# Altium schematic sheet Schematic Prints("All Documents",Physical)
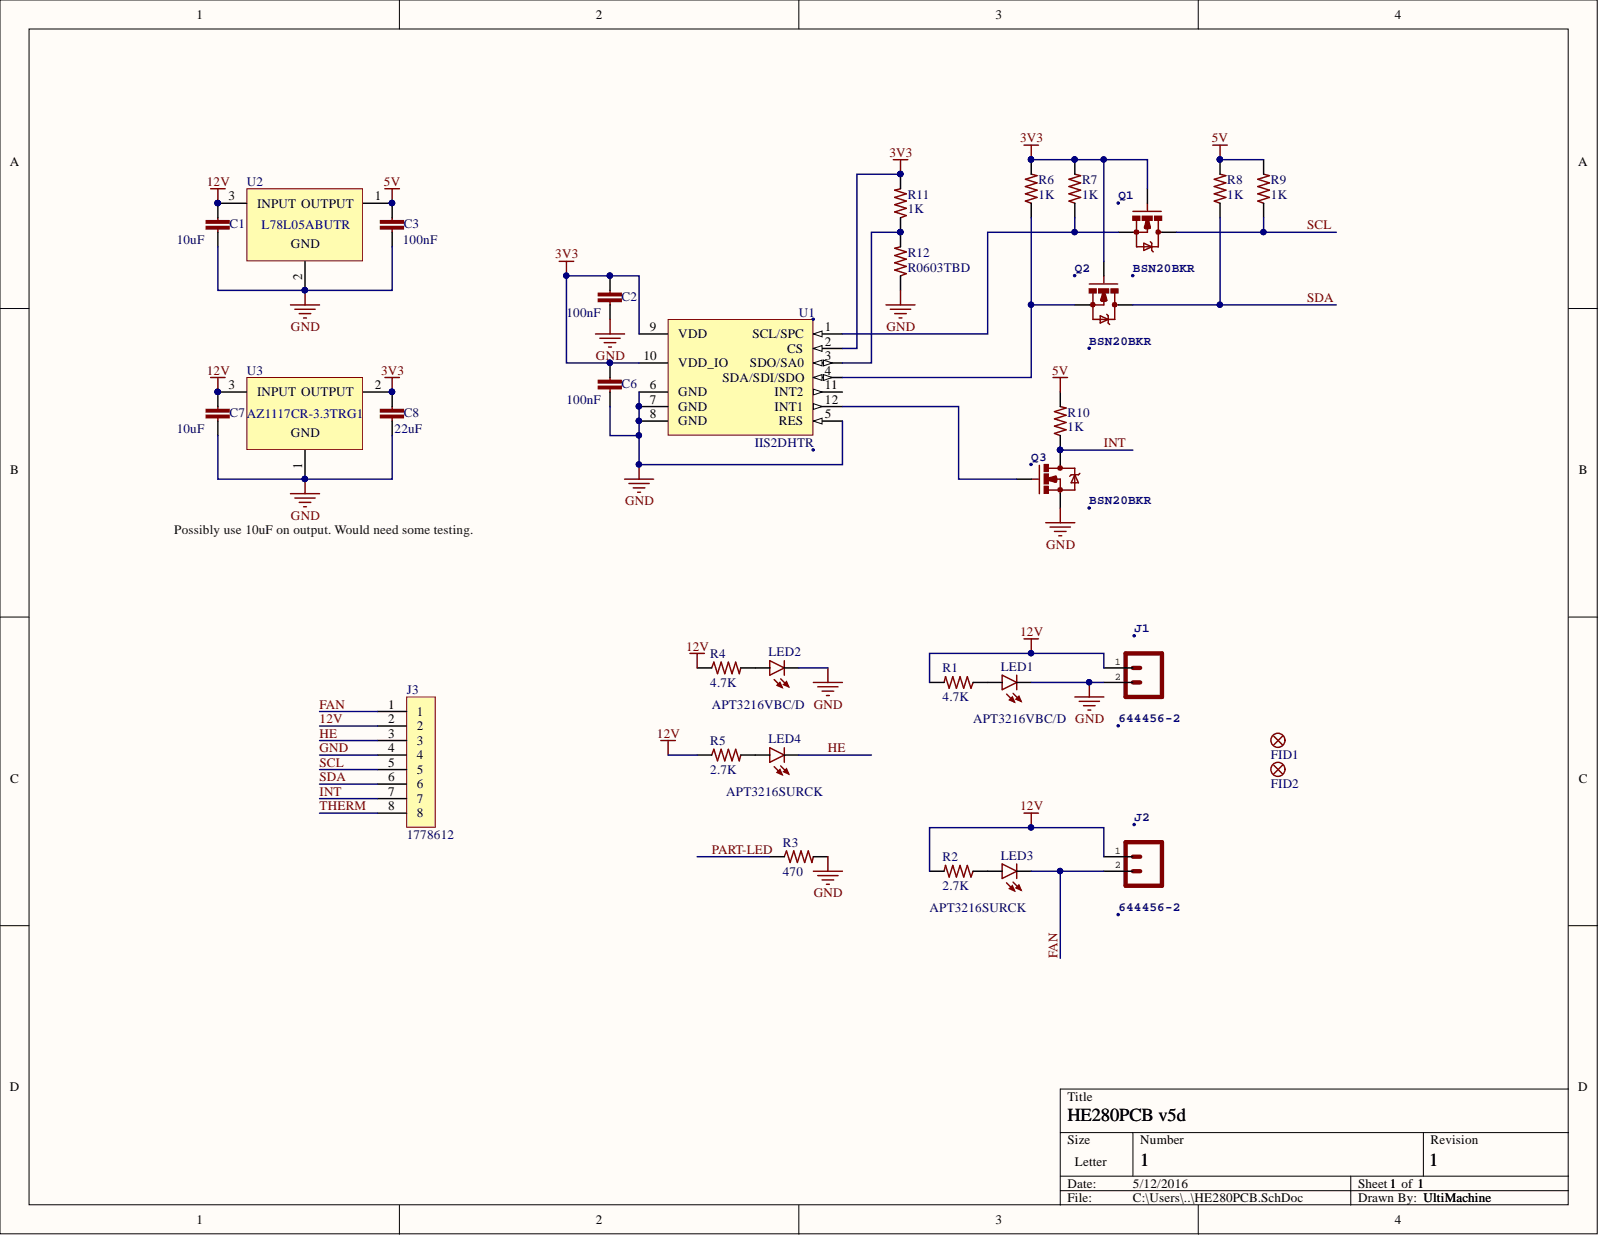
[diagram p0: Schematic Prints("All Documents",Physical)]

## Parts
C1  10uF  [C0805]
C2  100nF  [C0603]
C3  100nF  [C0603]
C6  100nF  [C0603]
C7  10uF  [C0805]
C8  22uF  [C0603]
FID1  FIDUCIAL  [FIDUCIAL-2x3-Panel]  (value not drawn)
FID2  FIDUCIAL  [FIDUCIAL-2x3-Panel]  (value not drawn)
J1  644456-2  [644456-2]
J2  644456-2  [644456-2]
J3  1778612  [1778612]
LED1  APT3216VBC/D  [LED1206]
LED2  APT3216VBC/D  [LED1206]
LED3  APT3216SURCK  [LED1206]
LED4  APT3216SURCK  [LED1206]
Q1  BSN20BKR  [SOT23-3]
Q2  BSN20BKR  [SOT23-3]
Q3  BSN20BKR  [SOT23-3]
R1  4.7K  [R0805]
R2  2.7K  [R0805]
R3  470  [R0805]
R4  4.7K  [R0805]
R5  2.7K  [R0805]
R6  1K  [R0603]
R7  1K  [R0603]
R8  1K  [R0603]
R9  1K  [R0603]
R10  1K  [R0603]
R11  1K  [R0603]
R12  R0603TBD  [R0603]
U1  IIS2DHTR  [LGA12C50P4X4_200X200X100]
U2  L78L05ABUTR  [SOT89-150P347X160-3L]
U3  AZ1117CR-3.3TRG1  [SOT89-150P347X160-3L]

## Nets
3V3: C2.1 C6.1 C8.1 Q1.1 Q2.1 R6.2 R7.2 R11.2 U1.2(CS) U1.9(VDD) U1.10(VDD_IO) U3.2
5V: C3.1 R8.2 R9.2 R10.2 U2.1
12V: C1.1 C7.1 J1.1 J2.1 J3.2 R1.2 R2.2 R4.1 R5.1 U2.3 U3.3
FAN: J2.2 J3.1 LED3.C
GND: C1.2 C2.2 C3.2 C6.2 C7.2 C8.2 J1.2 J3.4 LED1.C LED2.C Q3.2 R3.2 R12.1 U1.5(RES) U1.6(GND) U1.7(GND) U1.8(GND) U2.2 U3.1
HE: J3.3 LED4.C
INT: J3.7 Q3.3 R10.1
PART-LED: R3.1
SCL: J3.5 Q1.3 R9.1
SDA: J3.6 Q2.3 R8.1
THERM: J3.8
NetLED1_A: LED1.A R1.1
NetLED2_A: LED2.A R4.2
NetLED3_A: LED3.A R2.1
NetLED4_A: LED4.A R5.2
NetQ1_2: Q1.2 R7.1 U1.1(SCL/SPC)
NetQ2_2: Q2.2 R6.1 U1.4(SDA/SDI/SDO)
NetQ3_1: Q3.1 U1.12(INT1)
NetR11_1: R11.1 R12.2 U1.3(SDO/SA0)
NetU1_11: U1.11(INT2)

## Library data of the parts
C1 C7 = GRM21BR61C106KE15K: CAP CER 10UF 16V X5R 0805; LastRevisionNote: ImportComponents; Component Kind: Standard; LastRevisionDate: 23-Apr-2014; Library Name: 3Delight.SCHLIB; ManufacturerPartNumber: GRM21BR61C106KE15K; Published: 23-Apr-2014; Signal Integrity: Model Name; Simulation: Model Name; VALUE: 10uF; Publisher: UltiMachine
C2 C3 C6 = C0603C104K5RACTU: CAP CER 0603 100nF 50V 10% X7R; LastRevisionNote: ImportComponents; Component Kind: Standard; LastRevisionDate: 23-Apr-2014; Library Name: 3Delight.SCHLIB; ManufacturerPartNumber: C0603C104K5RACTU; Published: 23-Apr-2014; Signal Integrity: Model Name; Simulation: Model Name; VALUE: 100nF; Publisher: UltiMachine
C8 = GRM188R60J226MEA0J: CAP CER 22UF 6.3V X5R 0603; LastRevisionNote: ImportComponents; Component Kind: Standard; LastRevisionDate: 23-Apr-2014; Library Name: 3Delight.SCHLIB; ManufacturerPartNumber: GRM188R60J226MEA0J; Published: 23-Apr-2014; Signal Integrity: Model Name; Simulation: Model Name; VALUE: 22uF; Publisher: UltiMachine
FID1 FID2 = FIDUCIAL: LastRevisionNote: ImportComponents; Component Kind: Standard; Description: Fiducial; LastRevisionDate: 23-Apr-2014; Library Name: 3Delight.SCHLIB; Published: 23-Apr-2014; Publisher: UltiMachine
J1 J2 = 644456-2: Connector 2x1 2.54mm PTH; LastRevisionNote: ImportComponents; Component Kind: Standard; LastRevisionDate: 23-Apr-2014; Library Name: 3Delight.SCHLIB; Published: 23-Apr-2014; Publisher: UltiMachine
J3 = 1778612: Conn 2.5mm Pin in Paste Phoenix; Component Kind: Standard; Library Name: 3Delight.SCHLIB
LED1 LED2 = APT3216VBC/D: LED BLUE CLEAR 1206 SMD; LastRevisionNote: ImportComponents; Component Kind: Standard; LastRevisionDate: 23-Apr-2014; Library Name: 3Delight.SCHLIB; Published: 23-Apr-2014; VALUE: APT3216VBC/D; Publisher: UltiMachine
LED3 LED4 = APT3216SURCK: LED RED CLEAR 1206 SMD; LastRevisionNote: ImportComponents; Component Kind: Standard; LastRevisionDate: 23-Apr-2014; Library Name: 3Delight.SCHLIB; Published: 23-Apr-2014; VALUE: APT3216SURCK; Publisher: UltiMachine
Q1-Q3 = BSN20BKR: MOSFET N-CH 60V 0.265A SOT-23; LastRevisionNote: ImportComponents; Component Kind: Standard; LastRevisionDate: 23-Apr-2014; Library Name: 3Delight.SCHLIB; ManufacturerPartNumber: BSN20BKR; Published: 23-Apr-2014; Publisher: UltiMachine
R1 R4 = RC0805FR-074K7L: RES SMD 4.7K OHM 5% 1/8W 0805; LastRevisionNote: ImportComponents; Component Kind: Standard; LastRevisionDate: 23-Apr-2014; Library Name: 3Delight.SCHLIB; Published: 23-Apr-2014; Signal Integrity: Model Name; Simulation: Model Name; VALUE: 4.7K; Publisher: UltiMachine
R2 R5 = RC0805FR-072K7L: RES SMD 2.7K OHM 5% 1/8W 0805; LastRevisionNote: ImportComponents; Component Kind: Standard; LastRevisionDate: 23-Apr-2014; Library Name: 3Delight.SCHLIB; Published: 23-Apr-2014; Signal Integrity: Model Name; Simulation: Model Name; VALUE: 2.7K; Publisher: UltiMachine
R3 = RC0805JR-07470RL: RES SMD 470 OHM 5% 1/8W 0805; LastRevisionNote: ImportComponents; Component Kind: Standard; LastRevisionDate: 23-Apr-2014; Library Name: 3Delight.SCHLIB; Published: 23-Apr-2014; Signal Integrity: Model Name; Simulation: Model Name; VALUE: 470; Publisher: UltiMachine
R6-R11 = ERJ-3EKF1001V: RES 0603 1K 100mW 1%; LastRevisionNote: ImportComponents; Component Kind: Standard; LastRevisionDate: 23-Apr-2014; Library Name: 3Delight.SCHLIB; ManufacturerPartNumber: ERJ-3EKF1001V; Published: 23-Apr-2014; Signal Integrity: Model Name; Simulation: Model Name; VALUE: 1K; Publisher: UltiMachine
R12 = R0603TBD: Resistor; LastRevisionNote: ImportComponents; Component Kind: Standard; LastRevisionDate: 23-Apr-2014; Library Name: 3Delight.SCHLIB; Published: 23-Apr-2014; Signal Integrity: Model Name; Simulation: Model Name; VALUE: R0603TBD; Publisher: UltiMachine
U1 = IIS2DHTR: 3-axis accelerometer with digital output; Component Kind: Standard; Library Name: 3Delight.SCHLIB
U2 = L78L05ABUTR: IC REG LDO 5V 0.1A SOT89-3; Component Kind: Standard; Library Name: 3Delight.SCHLIB
U3 = AZ1117CR-3.3TRG1: IC REG LDO 3.3V 0.8A SOT89; Component Kind: Standard; Library Name: 3Delight.SCHLIB
